# Altium schematic sheet Power.SchDoc
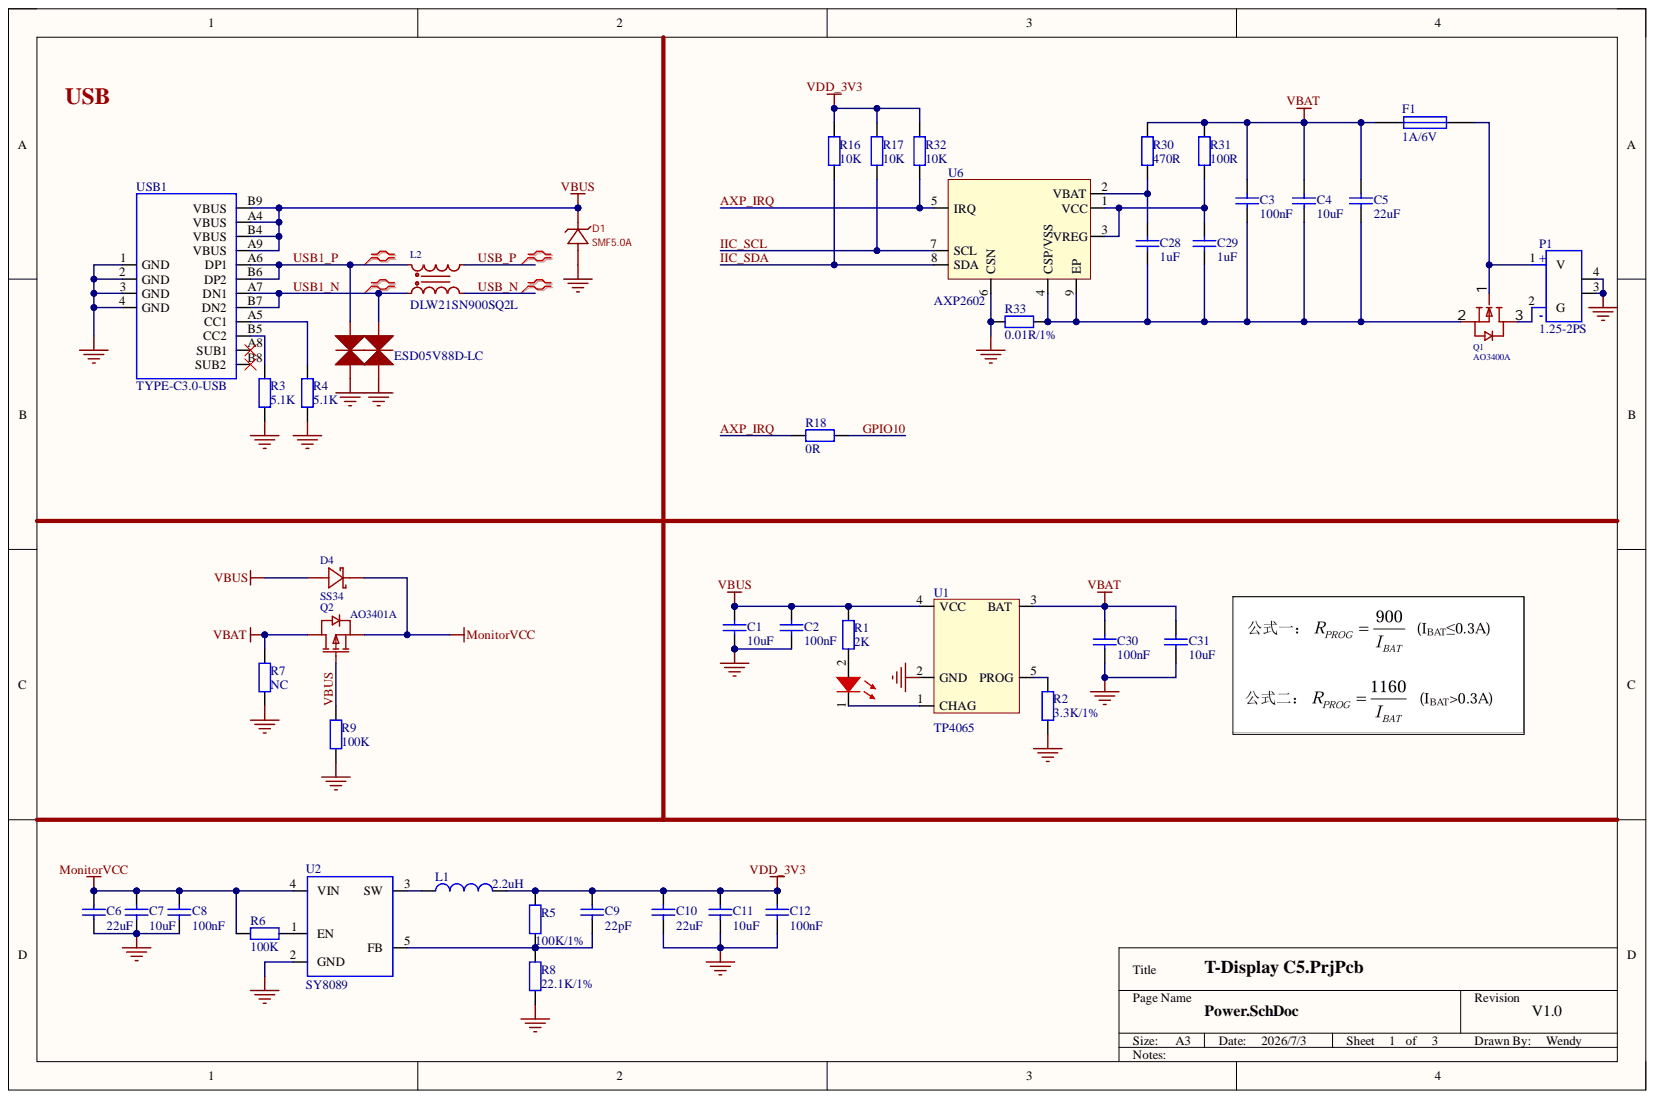
[diagram p0: Power.SchDoc]

## Parts
C1  10uF  [C0603]
C2  100nF  [C0402]
C3  100nF  [C0402]
C4  10uF  [C0402]
C5  22uF  [C0603]
C6  22uF  [C0603]
C7  10uF  [C0402]
C8  100nF  [C0402]
C9  22pF  [C0402]
C10  22uF  [C0603]
C11  10uF  [C0402]
C12  100nF  [C0402]
C28  1uF  [C0402]
C29  1uF  [C0402]
C30  100nF  [C0402]
C31  10uF  [C0402]
D1  SMF5.0A  [SOD-123FL_L2.7-W1.8-LS3.8-RD]
D2  (no value)  [DFN-SMD_L1.0-W0.6-BI]
D3  ESD05V88D-LC  [DFN-SMD_L1.0-W0.6-BI]
D4  SS34  [SMA_L4.3-W2.5-LS5.0-RD]
F1  1A/6V  [R0603]
L1  value 2.2uH  [L1008]
L2  DLW21SN900SQ2L  [FILTER-SMD_4P-L2.0-W1.2-BL_DLW21H]
LED1  NC  [LED0402]  (value not drawn)
P1  1.25-2PS  [CONN-SMD_2P-P1.25_DEALON_1.25-2PWB]
Q1  AO3400A  [SOT-23-3_L2.9-W1.3-P1.90-LS2.4-BR]
Q2  AO3401A  [SOT-23-3_L2.9-W1.3-P1.90-LS2.4-BR]
R1  2K  [R0402]
R2  3.3K/1%  [R0402]
R3  5.1K  [R0402]
R4  5.1K  [R0402]
R5  100K/1%  [R0402]
R6  100K  [R0402]
R7  NC  [R0402]
R8  22.1K/1%  [R0402]
R9  100K  [R0402]
R16  10K  [R0402]
R17  10K  [R0402]
R18  0R  [R0402]
R30  470R  [R0402]
R31  100R  [R0402]
R32  10K  [R0402]
R33  0.01R/1%  [R0805]
U1  TP4065  [SOT-23-5_L3.0-W1.7-P0.95-LS2.8-BR]
U2  SY8089  [SOT-23-5_L3.0-W1.7-P0.95-LS2.8-BR]
U6  AXP2602  [DFN-8_2*3mm]
USB1  TYPE-C3.0-USB  [TYPE-C3.0-USB]

## Nets
GND: C1.2 C2.2 C6.2 C7.2 C8.2 C10.2 C11.1 C12.1 C30.2 C31.2 D1.2 D2.2 D3.2 P1.3 P1.4 R2.1 R3.2 R4.2 R7.2 R8.1 R9.2 R33.1 U1.2(GND) U2.2(GND) U6.6(CSN) USB1.1(GND) USB1.2(GND) USB1.3(GND) USB1.4(GND) USB1.25 USB1.26 USB1.27 USB1.28 USB1.A1 USB1.A12 USB1.B1 USB1.B12
MonitorVCC: C6.1 C7.1 C8.1 D4.1 Q2.2 R6.2 U2.4(VIN)
VBAT: C3.1 C4.1 C5.1 C30.1 C31.1 F1.2 Q2.3 R7.1 R30.2 R31.2 U1.3(BAT)
VDD_3V3: C9.1 C10.1 C11.2 C12.2 L1.2 R5.2 R16.2 R17.2 R32.2
AXP_IRQ: R18.2 R32.1 U6.5(IRQ)
GPIO10: R18.1
IIC_SCL: R17.1 U6.7(SCL)
IIC_SDA: R16.1 U6.8(SDA)
USB1_N: D3.1 L2.4 USB1.A7(DN1) USB1.B7(DN2)
USB1_P: D2.1 L2.1 USB1.A6(DP1) USB1.B6(DP2)
USB_N: L2.3
USB_P: L2.2
VBUS: C1.1 C2.1 D1.1 D4.2 Q2.1 R1.2 R9.1 U1.4(VCC) USB1.A4(VBUS) USB1.A9(VBUS) USB1.B4(VBUS) USB1.B9(VBUS)
NetC3_2: C3.2 C4.2 C5.2 C28.1 C29.1 Q1.2 R33.2 U6.4(CSP/VSS) U6.9(EP)
NetC9_2: C9.2 R5.1 R8.2 U2.5(FB)
NetC28_2: C28.2 R30.1 U6.2(VBAT)
NetC29_2: C29.2 R31.1 U6.1(VCC) U6.3(VREG)
NetF1_1: F1.1 P1.1 Q1.1
NetL1_1: L1.1 U2.3(SW)
NetLED1_1: LED1.1 U1.1(CHAG)
NetLED1_2: LED1.2 R1.1
NetP1_2: P1.2 Q1.3
NetR2_2: R2.2 U1.5(PROG)
NetR3_1: R3.1 USB1.B5(CC2)
NetR4_1: R4.1 USB1.A5(CC1)
NetR6_1: R6.1 U2.1(EN)

Not connected: USB1 A8(SUB1) B8(SUB2)

## Library data of the parts
C1-C12 C28-C31 = Cap: Capacitor; Component Kind: Standard; LatestRevisionDate: 17-Jul-2002; LatestRevisionNote: Re-released for DXP Platform.; Library Name: Miscellaneous Devices.IntLib; PackageDescription: Radial Cap, Thru-Hole; 2 Leads; 0.3 in Pin Spacing; PackageReference: RAD-0.3; Published: 8-Jun-2000; Signal Integrity: Cap; Simulation: CAP; Publisher: Altium Limited
  C1 C4 C7 C11 C31: Value: 10uF
  C2 C3 C8 C12 C30: Value: 100nF
  C5 C6 C10: Value: 22uF
  C9: Value: 22pF
  C28 C29: Value: 1uF
D1 = SMF5.0A: TVS; Component Kind: Standard; Library Name: 常用.SCHLIB; 立创链接: https://item.szlcsc.com/231120.html
D2 D3 = ESD05V88D-LC: 系统型号: YLSMT101670; Component Kind: Standard; 立创链接: https://item.szlcsc.com/5751019.html; Library Name: Wendy Wen.SchLib
D4 = SS34_C727060: Manufacturer: TWGMC(台湾迪嘉); Add into BOM: yes; Diode Configuration: 独立式; Forward Voltage (Vf@If): 550mV@3A; Rectified Current: 3A; Reverse Leakage Current (Ir): 500uA; Reverse Voltage (Vr): 40V; Component Kind: Standard; Convert to PCB: yes; Datasheet: https://atta.szlcsc.com/upload/public/pdf/source/20200828/C727059_6599B6CAC5F3238B9C33E46794659DBE.pdf; Device: SS34_C727060; JLCPCB Part Class: Extended Part; LCSC Part Name: 电压:40V 电流:3A; Manufacturer Part: SS34; Supplier: LCSC; Supplier Footprint: SMA(DO-214AC); Supplier Part: C727060; Symbol: SS34_C727060
F1 = Fuse 1: 保险丝; Component Kind: Standard; LatestRevisionDate: 17-Jul-2002; LatestRevisionNote: Re-released for DXP Platform.; Library Name: Miscellaneous Devices.IntLib; PackageDescription: Thru-Hole; 2 Leads; PackageReference: PIN-W2/E2.8; Published: 8-Jun-2000; Simulation: FUSE; Publisher: Altium Limited
L1 = Inductor: 1239AS-H-2R2M=P2; Code_IEC: 1005; Code_JEITA: 0402; Component Kind: Standard; LatestRevisionDate: 29-May-2009; LatestRevisionNote: IPC-7351 Footprint Added.; Library Name: Miscellaneous Devices.IntLib; PackageDescription: Chip Inductor; PackageReference: 0402-A; Published: 8-Jun-2000; Signal Integrity: Inductor; Simulation: INDUCTOR; Publisher: Altium Limited
L2 = DLM11SN900HZ2L: Component Kind: Standard; Symbol: DLM11SN900HZ2L
LED1 = LED_Red: Component Kind: Standard; Library Name: WendyLib.SchLib
P1 = Battery: 1.25mm 1x2P 卧贴; 系统型号: 暂无; Component Kind: Standard; 立创链接: https://item.szlcsc.com/3172274.html; Library Name: WendyLib.SchLib
Q1 = AO3400A_C347475: Manufacturer: UMW(友台半导体); Add into BOM: yes; Continuous Drain Current (Id): 5.8A; Drain Source On Resistance (RDS(on)@Vgs,Id): 28mΩ@10V,5.8A; Drain Source Voltage (Vdss): 30V; Gate Threshold Voltage (Vgs(th)@Id): 1.4V@250uA; Input Capacitance (Ciss@Vds): 1.05nF@24V; Power Dissipation (Pd): 1.4W; Reverse Transfer Capacitance (Crss@Vds): 77pF@15V; Total Gate Charge (Qg@Vgs): 12nC@10V; Type: 1个N沟道; Component Kind: Standard; Convert to PCB: yes; Datasheet: https://atta.szlcsc.com/upload/public/pdf/source/20231109/50AB4FB4D66E55864F9B8D027B723C9A.pdf; Device: AO3400A_C347475; JLCPCB Part Class: Extended Part; LCSC Part Name: 1个N沟道 耐压:30V 电流:5.8A; Manufacturer Part: AO3400A; Operating Temperature: -55℃~+150℃; PCB3D: SOT-23-3P_L2.9-W1.3-H1.0-LS2.4-P0.95; Supplier: LCSC; Supplier Footprint: SOT-23; Supplier Part: C347475; Symbol: AO3400A_C347475; Library Name: WendyLib.SchLib
Q2 = SI2301_C727151: Manufacturer: TWGMC(台湾迪嘉); Manufacturer Part: SI2301; Add into BOM: yes; Continuous Drain Current (Id): 2.8A; Drain Source On Resistance (RDS(on)@Vgs,Id): 112mΩ@4.5V,2.8A; Drain Source Voltage (Vdss): 20V; Gate Threshold Voltage (Vgs(th)@Id): 1V@250uA; Input Capacitance (Ciss@Vds): 405pF@10V; Power Dissipation (Pd): 350mW; Reverse Transfer Capacitance (Crss@Vds): 55pF@10V; Type: 1个P沟道; Component Kind: Standard; Convert to PCB: yes; Datasheet: https://atta.szlcsc.com/upload/public/pdf/source/20200827/C727151_305C77401298A97EBFD5A496568D8C6C.pdf; Device: SI2301_C727151; JLCPCB Part Class: Extended Part; LCSC Part Name: 1个P沟道 耐压:20V 电流:2.8A; Supplier: LCSC; Supplier Footprint: SOT-23; Supplier Part: C727151; Symbol: SI2301_C727151; Total Gate Charge (Qg@Vgs): -
R1-R9 R16-R18 R30-R33 = Res2: Resistor; Component Kind: Standard; LatestRevisionDate: 17-Jul-2002; LatestRevisionNote: Re-released for DXP Platform.; Library Name: Miscellaneous Devices.IntLib; PackageDescription: Axial Device, Thru-Hole; 2 Leads; 0.4 in Pin Spacing; PackageReference: AXIAL-0.4; Published: 8-Jun-2000; Signal Integrity: Res; Simulation: RESISTOR; Publisher: Altium Limited
  R1: Value: 2K
  R2: Value: 3.3K/1%
  R3 R4: Value: 5.1K
  R5: Value: 100K/1%
  R6 R9: Value: 100K
  R7: Value: NC
  R8: Value: 22.1K/1%
  R16 R17 R32: Value: 10K
  R18: Value: 0R
  R30: Value: 470R
  R31: Value: 100R
  R33: Value: 0.01R/1%
U2 = TLV62569: Component Kind: Standard; Library Name: Schlib1.SchLib
USB1 = TYPE-C 16P CB1.6 073: Component Kind: Standard; Library Name: WendyLib.SchLib
U1 = TP4065: Component Kind: Standard; Library Name: WendyLib.SchLib
U6 = AXP2602: Component Kind: Standard; Library Name: WendyLib.SchLib
